# Altium schematic sheet 04_Main.SchDoc
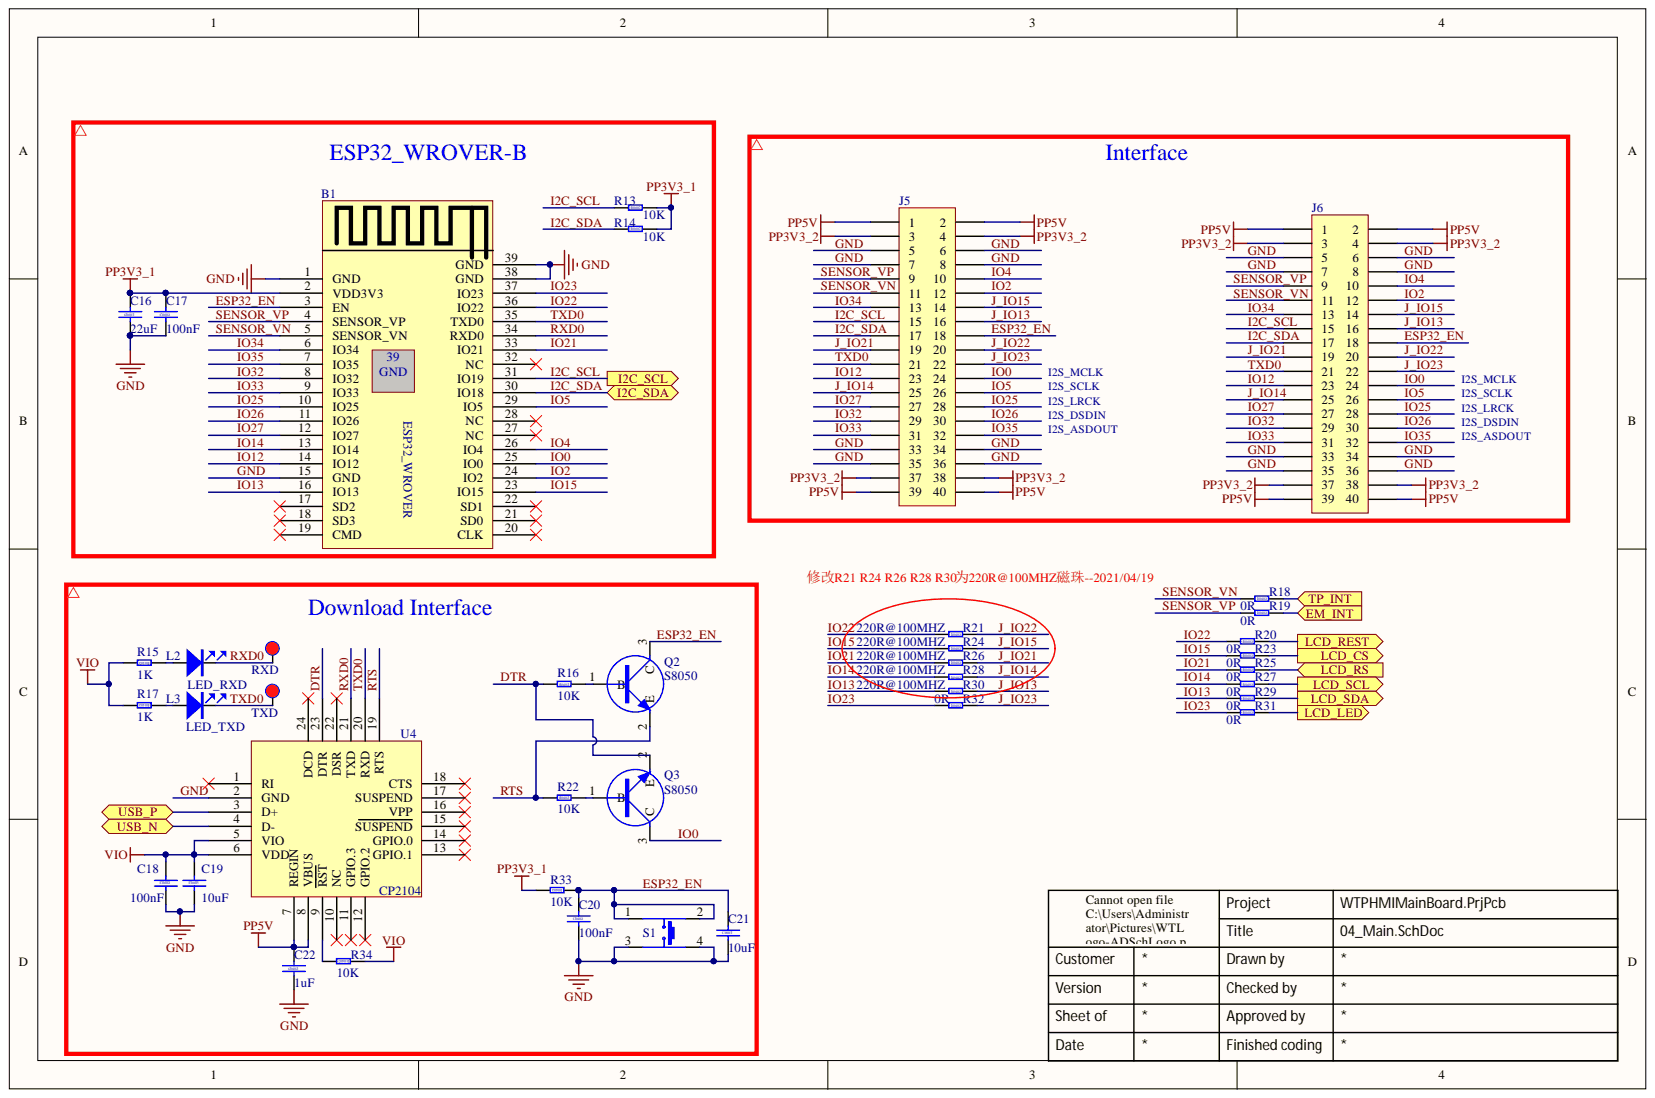
[diagram p0: 04_Main.SchDoc]

## Parts
B1  ESP32_WROVER  [ESP32_WROVER]
C16  22uF  [C0603]
C17  100nF  [C0402]
C18  100nF  [C0402]
C19  10uF  [C0603]
C20  100nF  [C0402]
C21  10uF  [C0603]
C22  1uF  [C0402]
J5  FAR2851-4001A01B1BC  [CON2X20P-2R0-SM-W2]  (value not drawn)
J6  FAR2851-4001A01B1BC  [CON2X20P-2R0-SM-W2]  (value not drawn)
L2  LED_RXD  [LED0603]
L3  LED_TXD  [LED0603]
Q2  S8050  [SOT-23]
Q3  S8050  [SOT-23]
R13  10K  [R0402]
R14  10K  [R0402]
R15  1K  [R0402]
R16  10K  [R0402]
R17  1K  [R0402]
R18  0R  [R0402]
R19  0R  [R0402]
R20  0R  [R0402]
R21  220R@100MHZ  [R0402]
R22  10K  [R0402]
R23  0R  [R0402]
R24  220R@100MHZ  [R0402]
R25  0R  [R0402]
R26  220R@100MHZ  [R0402]
R27  0R  [R0402]
R28  220R@100MHZ  [R0402]
R29  0R  [R0402]
R30  220R@100MHZ  [R0402]
R31  0R  [R0402]
R32  0R  [R0402]
R33  10K  [R0402]
R34  10K  [R0402]
RXD  TestPiont  [TP]  (value not drawn)
S1  Key-DZY-3x4  [KEY-DZY-3X4]  (value not drawn)
TXD  TestPiont  [TP]  (value not drawn)
U4  CP2104  [QFN24-0R5-4X4-T]

## Ports
EM_INT, I2C_SCL, I2C_SDA, LCD_CS, LCD_LED, LCD_REST, LCD_RS, LCD_SCL, LCD_SDA, TP_INT, USB_N, USB_P

## Nets
PP3V3_1: B1.2(VDD3V3) C16.1 C17.1 R13.2 R14.2 R33.2
PP3V3_2: J5.3 J5.4 J5.37 J5.38 J6.3 J6.4 J6.37 J6.38
PP5V: C22.1 J5.1 J5.2 J5.39 J5.40 J6.1 J6.2 J6.39 J6.40 U4.7(REGIN) U4.8(VBUS)
VIO: C18.1 C19.1 R15.2 R17.2 R34.1 U4.5(VIO) U4.6(VDD)
DTR: Q3.2 R16.1 U4.23(DTR)
EM_INT: R19.2 ; port EM_INT
ESP32_EN: B1.3(EN) C20.1 C21.1 J5.18 J6.18 Q2.3 R33.1 S1.1 S1.2
GND: B1.1(GND) B1.15(GND) B1.38(GND) B1.39(GND) C16.2 C17.2 C18.2 C19.2 C20.2 C21.2 C22.2 J5.5 J5.6 J5.7 J5.8 J5.33 J5.34 J5.35 J5.36 J6.5 J6.6 J6.7 J6.8 J6.33 J6.34 J6.35 J6.36 S1.3 S1.4 U4.2(GND)
I2C_SCL: B1.31(IO19) J5.15 J6.15 R13.1 ; port I2C_SCL
I2C_SDA: B1.30(IO18) J5.17 J6.17 R14.1 ; port I2C_SDA
IO0: B1.25(IO0) J5.24 J6.24 Q3.3
IO2: B1.24(IO2) J5.12 J6.12
IO4: B1.26(IO4) J5.10 J6.10
IO5: B1.29(IO5) J5.26 J6.26
IO12: B1.14(IO12) J5.23 J6.23
IO13: B1.16(IO13) R29.1 R30.1
IO14: B1.13(IO14) R27.1 R28.1
IO15: B1.23(IO15) R23.1 R24.1
IO21: B1.33(IO21) R25.1 R26.1
IO22: B1.36(IO22) R20.1 R21.1
IO23: B1.37(IO23) R31.1 R32.1
IO25: B1.10(IO25) J5.28 J6.28
IO26: B1.11(IO26) J5.30 J6.30
IO27: B1.12(IO27) J5.27 J6.27
IO32: B1.8(IO32) J5.29 J6.29
IO33: B1.9(IO33) J5.31 J6.31
IO34: B1.6(IO34) J5.13 J6.13
IO35: B1.7(IO35) J5.32 J6.32
J_IO13: J5.16 J6.16 R30.2
J_IO14: J5.25 J6.25 R28.2
J_IO15: J5.14 J6.14 R24.2
J_IO21: J5.19 J6.19 R26.2
J_IO22: J5.20 J6.20 R21.2
J_IO23: J5.22 J6.22 R32.2
LCD_CS: R23.2 ; port LCD_CS
LCD_LED: R31.2 ; port LCD_LED
LCD_REST: R20.2 ; port LCD_REST
LCD_RS: R25.2 ; port LCD_RS
LCD_SCL: R27.2 ; port LCD_SCL
LCD_SDA: R29.2 ; port LCD_SDA
RTS: Q2.2 R22.1 U4.19(RTS)
RXD0: B1.34(RXD0) L2.2 RXD.1 U4.21(TXD)
SENSOR_VN: B1.5(SENSOR_VN) J5.11 J6.11 R18.1
SENSOR_VP: B1.4(SENSOR_VP) J5.9 J6.9 R19.1
TP_INT: R18.2 ; port TP_INT
TXD0: B1.35(TXD0) J5.21 J6.21 L3.2 TXD.1 U4.20(RXD)
USB_N: U4.4(D-) ; port USB_N
USB_P: U4.3(D+) ; port USB_P
NetL2_1: L2.1 R15.1
NetL3_1: L3.1 R17.1
NetQ2_1: Q2.1 R16.2
NetQ3_1: Q3.1 R22.2
NetR34_2: R34.2 U4.9(RST)

Not connected: B1 17(SD2) 18(SD3) 19(CMD) 20(CLK) 21(SD0) 22(SD1) 27(NC) 28(NC) 32(NC); U4 1(RI) 10(NC) 11(GPIO.3) 12(GPIO.2) 13(GPIO.1) 14(GPIO.0) 15(SUSPEND) 16(VPP) 17(SUSPEND) 18(CTS) 22(DSR) 24(DCD)

## Library data of the parts
B1 = ESP32_WROVER: 乐鑫ESP32_WROVER模块，39Pin; Component Kind: Standard; Library Name: YanLibrary_PCBA.SchLib
C16 C19 C21 = C0603: Component Kind: Standard; Library Name: Gateway.SchLib
C17 C18 C20 C22 = C0402: Component Kind: Standard; Library Name: Gateway.SchLib
J5 J6 = 102NO-00019DJ.01: DIP,封装脚距2.54mm,90度,2x20PIN,塑高/针长5.0/3.2mm,黑色; Allow Altenative Part: Y; Approved: YES; Categories: 连接器; COMPONENTLINK 1 DESCRIPTION: GetDatasheet; Database Table Name: Connector; Modify time: 2016年11月20号; Remark: Verified by CRD（赖程奇） check list V1.0; serial number: 214; Category: 双排母; Component Kind: Standard; COMPONENTLINK 1 URL: http://www.hkjb888.com/product.asp?action=detailed&id=1079&page=6; Library Name: IA-04.DbLib; Mounting Type: ThroughHole; PACKAGE: Bulk; Part Number: 102NO-00019DJ.01; Pricing 1: 43.5; Supplier 1: 骏佳; Supplier Part Number 1: FAR2851-4001A01B1BC; Value: FAR2851-4001A01B1BC
L2 L3 = LED: Component Kind: Standard; PAK: LED0603
Q2 Q3 = NPN: Component Kind: Standard; Library Name: Gateway.SchLib; PAK: SOT-23
R13-R34 = R0402: Component Kind: Standard; Library Name: Gateway.SchLib
S1 = Key-DZY-3x4: 电子烟按钮 自复位 3x4; Sort: CONNECT; Value: Tact switch; Component Kind: Standard; PackageDescription: Tact switch, 轻触开关，四脚，贴片, 非自锁; PackageReference: KEY_4PIN; Library Name: YanLibrary_Connector.SchLib
U4 = CP2104: USB转UART; Component Kind: Standard; Library Name: YanLibrary_IC.SchLib
RXD TXD = TestPiont: Component Kind: Standard
